# Altium schematic sheet Extra9_LCD.SchDoc
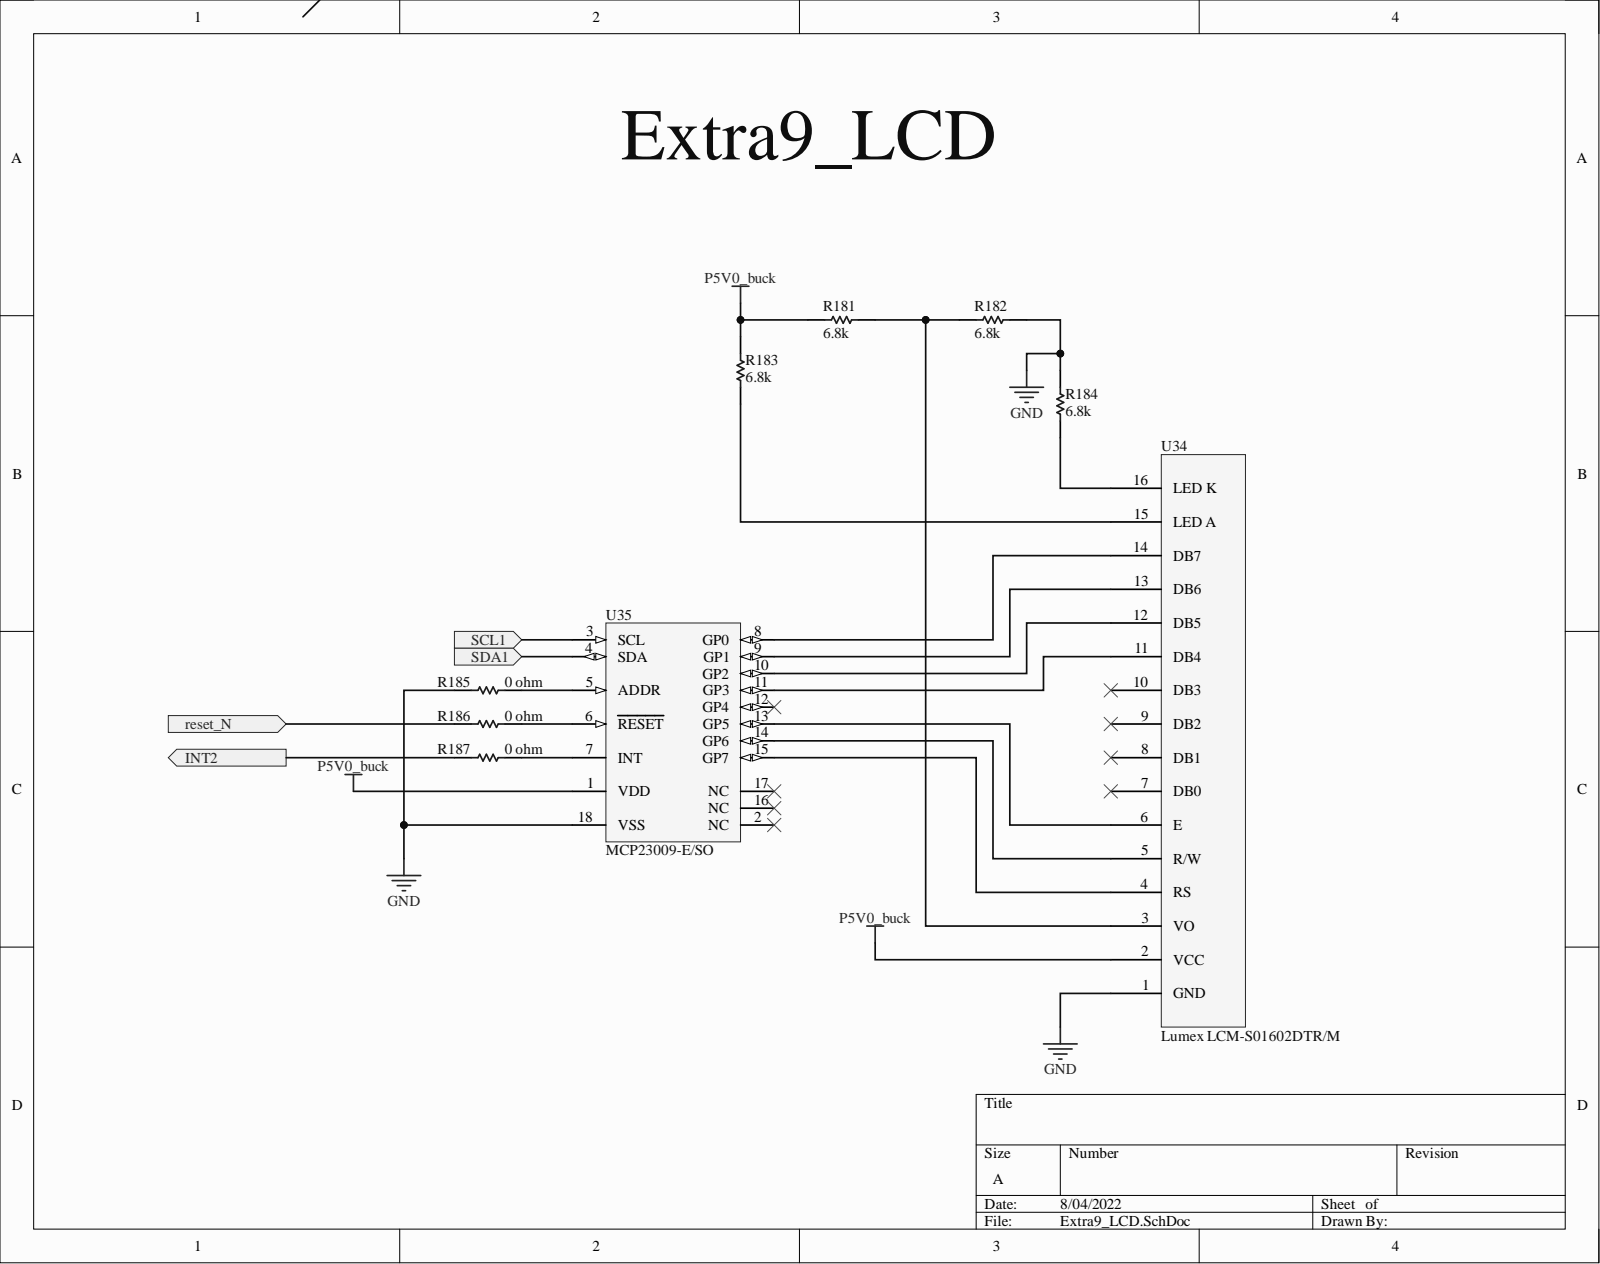
[diagram p0: Extra9_LCD.SchDoc]

## Parts
R181  6.8k  [RESC2013X55X40NL15T20]
R182  6.8k  [RESC2013X55X40NL15T20]
R183  6.8k  [RESC2013X55X40NL15T20]
R184  6.8k  [RESC2013X55X40NL15T20]
R185  0 ohm  [RESC2013X50X30LL20T20]
R186  0 ohm  [RESC2013X50X30LL20T20]
R187  0 ohm  [RESC2013X50X30LL20T20]
U34  Lumex LCM-S01602DTR/M  Manufacturer Part Number: LCM-S01602DTR/M  [PCBComponent_1]
U35  MCP23009-E/SO  [SOIC-SO18_L]

## Ports
INT2, reset_N, SCL1, SDA1

## Nets
GND: R182.2 R184.2 R185.1 U34.1(GND) U35.18(VSS)
P5V0_buck: R181.1 R183.2 U34.2(VCC) U35.1(VDD)
INT2: R187.1 ; port INT2
reset_N: R186.1 ; port reset_N
SCL1: U35.3(SCL) ; port SCL1
SDA1: U35.4(SDA) ; port SDA1
NetR181_2: R181.2 R182.1 U34.3(VO)
NetR183_1: R183.1 U34.15(LED_A)
NetR184_1: R184.1 U34.16(LED_K)
NetR185_2: R185.2 U35.5(ADDR)
NetR186_2: R186.2 U35.6(RESET)
NetR187_2: R187.2 U35.7(INT)
NetU34_4: U34.4(RS) U35.15(GP7)
NetU34_5: U34.5(R/W) U35.14(GP6)
NetU34_6: U34.6(E) U35.13(GP5)
NetU34_11: U34.11(DB4) U35.11(GP3)
NetU34_12: U34.12(DB5) U35.10(GP2)
NetU34_13: U34.13(DB6) U35.9(GP1)
NetU34_14: U34.14(DB7) U35.8(GP0)

Not connected: U34 7(DB0) 8(DB1) 9(DB2) 10(DB3); U35 2(NC) 12(GP4) 16(NC) 17(NC)

## Library data of the parts
R181 = CMP-1953953-15: RES SMD 6.8K OHM 0.1% 1/8W 0805; Manufacturer Part Number: TNPW080510K0BEEN; Case/Package (SI): 2012; Lead-Free Status: Lead Free; Power Rating: 0.125 W; ReplaceComponentId: JOEPMQPD; Resistance: 6800.0 Ω; Temperature Coefficient: 25.0 ppm/°C; Voltage Rating (DC): 150.0 V; Case/Package: 0805; Component Kind: Standard; Library Name: My Personal Space; Number of Pins: 2; OctopartID: 2ed9e58acfb9f11e; Packaging: Tape & Reel (TR); PartId: 1; Resistance Tolerance: ±0.1%; RoHS: Compliant
R182 = CMP-1953953-15: RES SMD 6.8K OHM 0.1% 1/8W 0805; Manufacturer Part Number: TNPW080510K0BEEN; Case/Package (SI): 2012; Lead-Free Status: Lead Free; Power Rating: 0.125 W; ReplaceComponentId: VHZGZLNK; Resistance: 6800.0 Ω; Temperature Coefficient: 25.0 ppm/°C; Voltage Rating (DC): 150.0 V; Case/Package: 0805; Component Kind: Standard; Library Name: My Personal Space; Number of Pins: 2; OctopartID: 2ed9e58acfb9f11e; Packaging: Tape & Reel (TR); PartId: 1; Resistance Tolerance: ±0.1%; RoHS: Compliant
R183 = CMP-1953953-15: RES SMD 6.8K OHM 0.1% 1/8W 0805; Manufacturer Part Number: TNPW080510K0BEEN; Case/Package (SI): 2012; Lead-Free Status: Lead Free; Power Rating: 0.125 W; ReplaceComponentId: BGJIZUMT; Resistance: 6800.0 Ω; Temperature Coefficient: 25.0 ppm/°C; Voltage Rating (DC): 150.0 V; Case/Package: 0805; Component Kind: Standard; Library Name: My Personal Space; Number of Pins: 2; OctopartID: 2ed9e58acfb9f11e; Packaging: Tape & Reel (TR); PartId: 1; Resistance Tolerance: ±0.1%; RoHS: Compliant
R184 = CMP-1953953-15: RES SMD 6.8K OHM 0.1% 1/8W 0805; Manufacturer Part Number: TNPW080510K0BEEN; Case/Package (SI): 2012; Lead-Free Status: Lead Free; Power Rating: 0.125 W; ReplaceComponentId: CQYJUFJH; Resistance: 6800.0 Ω; Temperature Coefficient: 25.0 ppm/°C; Voltage Rating (DC): 150.0 V; Case/Package: 0805; Component Kind: Standard; Library Name: My Personal Space; Number of Pins: 2; OctopartID: 2ed9e58acfb9f11e; Packaging: Tape & Reel (TR); PartId: 1; Resistance Tolerance: ±0.1%; RoHS: Compliant
R185-R187 = CMP-1904075-2: RES SMD 0.0 OHM JUMPER 1/8W 0805; Manufacturer Part Number: CRCW08050000ZSTA; Case/Package (SI): 2012; Lead-Free Status: Contains Lead; Power Dissipation: 0.125 W; Resistance: 0.0 Ω; Resistance Tolerance: ±1%; Voltage Rating (DC): 150.0 V; Component Kind: Standard; Library Name: My Personal Space; Mounting Style: Surface Mount; Number of Pins: 2; Octopart Url: https://octopart.com/crcw08050000zsta-vishay-39388113; OctopartID: 3b91f0c21d26e456; Power Rating: 0.125 W; REACH SVHC Compliance: No SVHC; RoHS: Non-Compliant; Size-Height: 0.00045 m; Size-Length: 0.002 m; Size-Width: 0.00125 m
U34 = CMP-ec102512921233d7-6: Lumex Lcm-S01602dtr/m / Lcd Module 16x2 Character Lumex; Character Size-Height: 5.56 mm; Lead-Free Status: Lead Free; Supply Voltage (DC): 5.00 V; Component Kind: Standard; Library Name: My Personal Space; Octopart URL: http://octopart.com/lcm-s01602dtr%2Fm-lumex-547684; OctopartID: ec102512921233d7; Packaging: Bulk; RoHS: Compliant; Size-Height: 6.50 mm; Size-Length: 66.0 mm; Size-Width: 26.0 mm
U35 = CMP-1159035-3: 8-Bit I/O Expander with Open-Drain Outputs, High-Speed I2C Interface, 18-Pin SOIC, Extended Temperature; Lead-Free Status: Lead Free; Supply Current: 0.001 A; Case/Package: SOIC; Component Kind: Standard; Library Name: My Personal Space; Lifecycle Status: Active; Manufacturer Part Number: MCP23009-E/SO; Mounting Style: Surface Mount; Number of Pins: 18; Octopart Url: https://octopart.com/mcp23009-e%2Fso-microchip-9416504; OctopartID: 2073e9f6aa2dffb4; REACH SVHC Compliance: No SVHC; RoHS: Compliant
